# Altium schematic sheet PAGE05_机场端协议.SchDoc
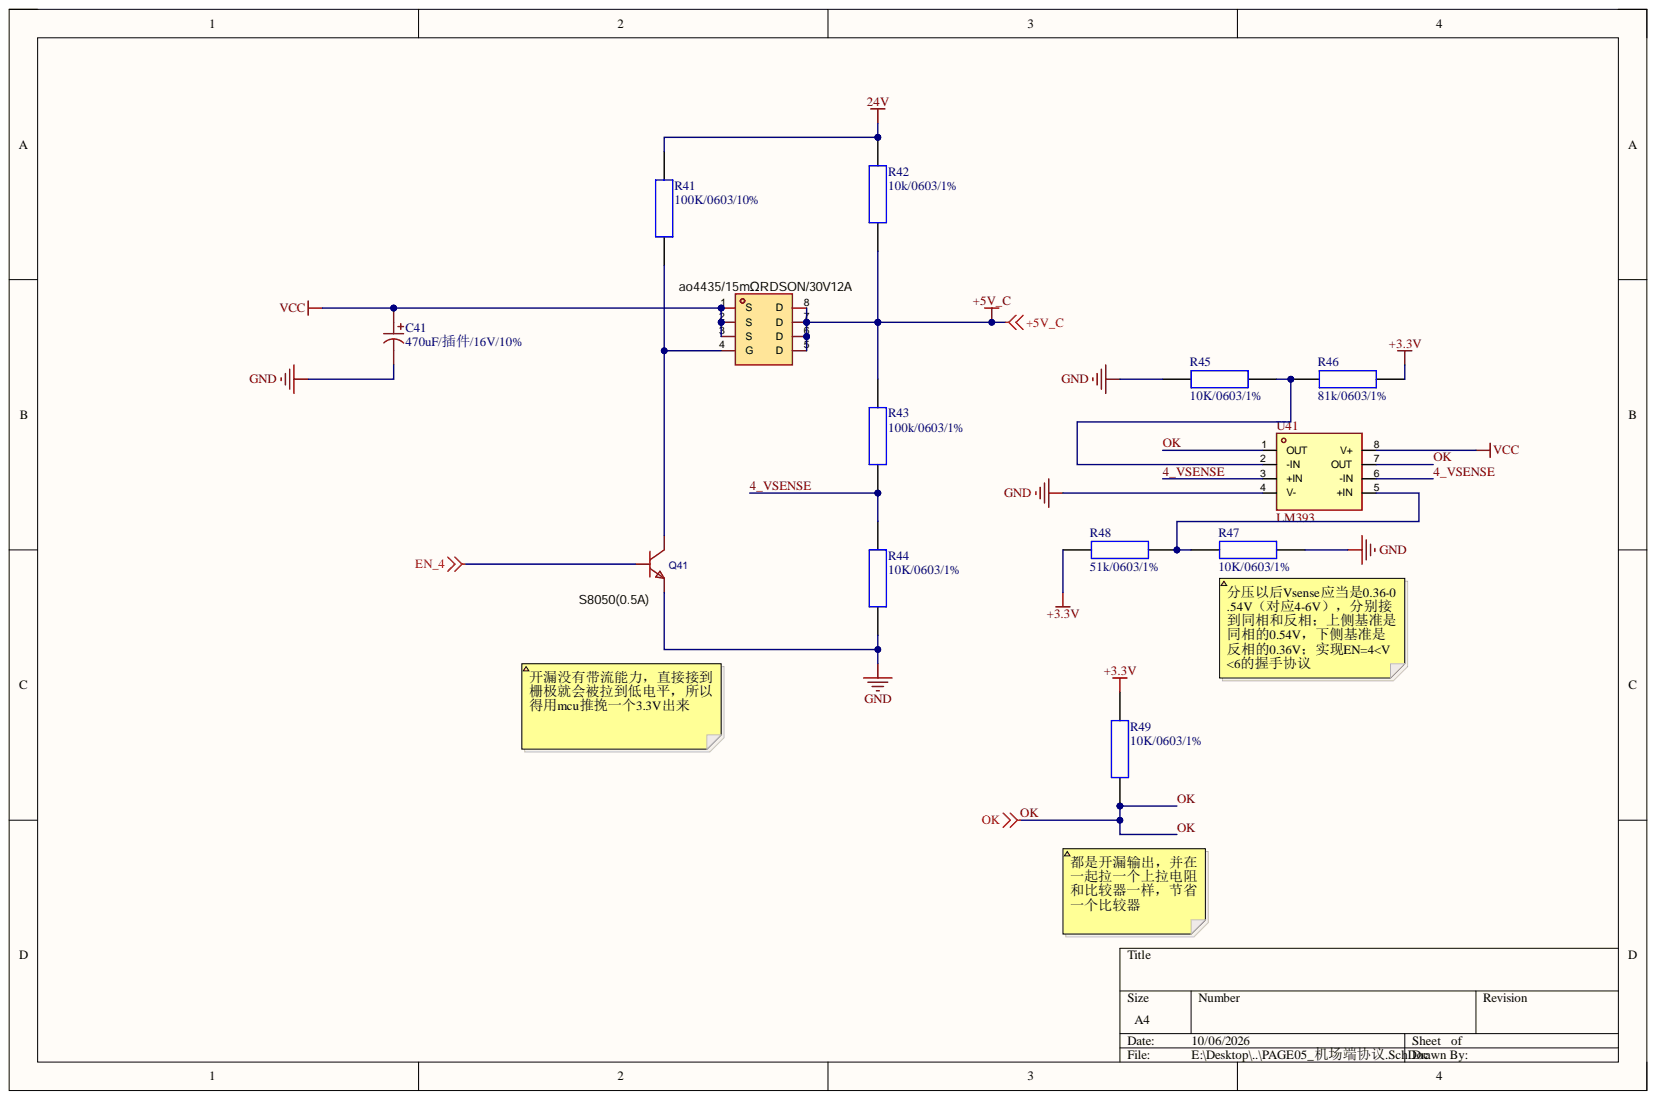
[diagram p0: PAGE05_机场端协议.SchDoc]

## Parts
C41  470uF/插件/16V/10%  [CAP-TH_BD10.0-P5.00-D0.6-FD-A]
Q41  S8050(0.5A)  [SOT-23-3_L2.9-W1.3-P1.90-LS2.4-BR]
Q42  ao4435/15mΩRDSON/30V12A  [SOP-8_L5.0-W3.8-P1.27-LS6.0-BL]
R41  100K/0603/10%  [0603_ding_R]
R42  10k/0603/1%  [0603_ding_R]
R43  100k/0603/1%  [0603_ding_R]
R44  10K/0603/1%  [0603_ding_R]
R45  10K/0603/1%  [0603_ding_R]
R46  81k/0603/1%  [0603_ding_R]
R47  10K/0603/1%  [0603_ding_R]
R48  51k/0603/1%  [0603_ding_R]
R49  10K/0603/1%  [0603_ding_R]
U41  LM393  [SOIC-8_L5.0-W4.0-P1.27-LS6.0-BL]

## Nets
24V: R41.2 R42.2
+3.3V: R46.1 R48.1 R49.1
+5V_C: Q42.5(D) Q42.6(D) Q42.7(D) Q42.8(D) R42.1 R43.2
EN_4: Q41.1
GND: C41.2 Q41.2 R44.1 R45.2 R47.2 U41.4(V-)
VCC: C41.1 Q42.1(S) Q42.2(S) Q42.3(S) U41.8(V+)
4_VSENSE: R43.1 R44.2 U41.3(+IN) U41.6(-IN)
OK: R49.2 U41.1(OUT) U41.7(OUT)
NetQ41_3: Q41.3 Q42.4(G) R41.1
NetR45_1: R45.1 R46.2 U41.2(-IN)
NetR47_1: R47.1 R48.2 U41.5(+IN)

## Library data of the parts
C41 = Cbig: Component Kind: Standard; Library Name: IP6826.SchLib
Q41 = s8050(0.5a): 晶体管类型:NPN;集电极电流(Ic):500mA;集射极击穿电压(Vceo):25V;耗散功率(Pd):300mW;直流电流增益(hFE):120;; Manufacturer: EIC; AddInBom: yes; Collector - Emitter Voltage VCEO: 25V; Current - Collector Cutoff: 100nA; Current - Collector(Ic): 500mA; DC Current Gain: 120; Emitter-Base Voltage(Vebo): 5V; ModelId: c62791f0466c4ae2a892c056b845b0ca; ModelName: SOT-23-3P_L2.9-W1.3-H1.0-LS2.4-P0.95; ModelTransform: 98.425,114.173,0,180,0,0,0.0005,-0.0005,0; Number: 1个NPN; Pd - Power Dissipation: 300mW; Transition frequency(fT): 150MHz; type: NPN; Vce Saturation(VCE(sat)): 600mV; Component Kind: Standard; ConvertToPcb: yes; Datasheet: https://item.szlcsc.com/datasheet/S8050(0.5A)/3524496.html; FootprintName: SOT-23-3_L2.9-W1.3-P1.90-LS2.4-BR; JLCPCB Part Class: Extended Part; LCSC Part Name: 电流:0.5A 电压:25V; Library Name: S8050(0.5A).SCHLIB; ManufacturerPart: S8050(0.5A); Supplier: LCSC; Supplier Footprint: SOT-23; SupplierPart: C3014350; Symbol: S8050(0.5A)
Q42 = ao4435: 数量:1个P沟道;漏源电压(Vdss):30V;连续漏极电流(Id):12A;导通电阻(RDS(on)):15mΩ@10V;耗散功率(Pd):2.5W;阈值电压(Vgs(th)):3V;; Manufacturer: UMW(友台半导体); AddInBom: yes; Current - Continuous Drain(Id): 12A; Drain to Source Voltage: 30V; Gate Charge(Qg): 18nC; Gate Threshold Voltage (Vgs(th)): 3V; Input Capacitance(Ciss): 1.175nF; ModelId: 823e4ad1ee284f09bfed9f55dae295e0; ModelTransform: 196.85,236.22,0,0,0,0,0,0,0; Number: 1个P沟道; Output Capacitance(Coss): 195pF; Pd - Power Dissipation: 2.5W; Reverse Transfer Capacitance (Crss@Vds): 190pF; Symbol: AO4435_C2944310; Component Kind: Standard; ConvertToPcb: yes; Datasheet: https://item.szlcsc.com/datasheet/AO4435/3330325.html; FootprintName: SOP-8_L5.0-W3.8-P1.27-LS6.0-BL; JLCPCB Part Class: Extended Part; LCSC Part Name: 1个P沟道 耐压:30V 电流:12A; Library Name: AO4435_C2944310.SCHLIB; ManufacturerPart: AO4435; ModelName: SOP-8_L5.0-W3.8-P1.27-LS6.0-BL; Operating Temperature: -55℃~+150℃; RDS(on): 15mΩ@10V; Supplier: LCSC; Supplier Footprint: SOP-8; SupplierPart: C2944310
R41-R49 = RES: Component Kind: Standard; Library Name: IP6826.SchLib
U41 = LM393: Component Kind: Standard; Library Name: IP6826.SchLib
